# Altium schematic sheet [03] PD_SNK.SchDoc("[03] PD_SNK")
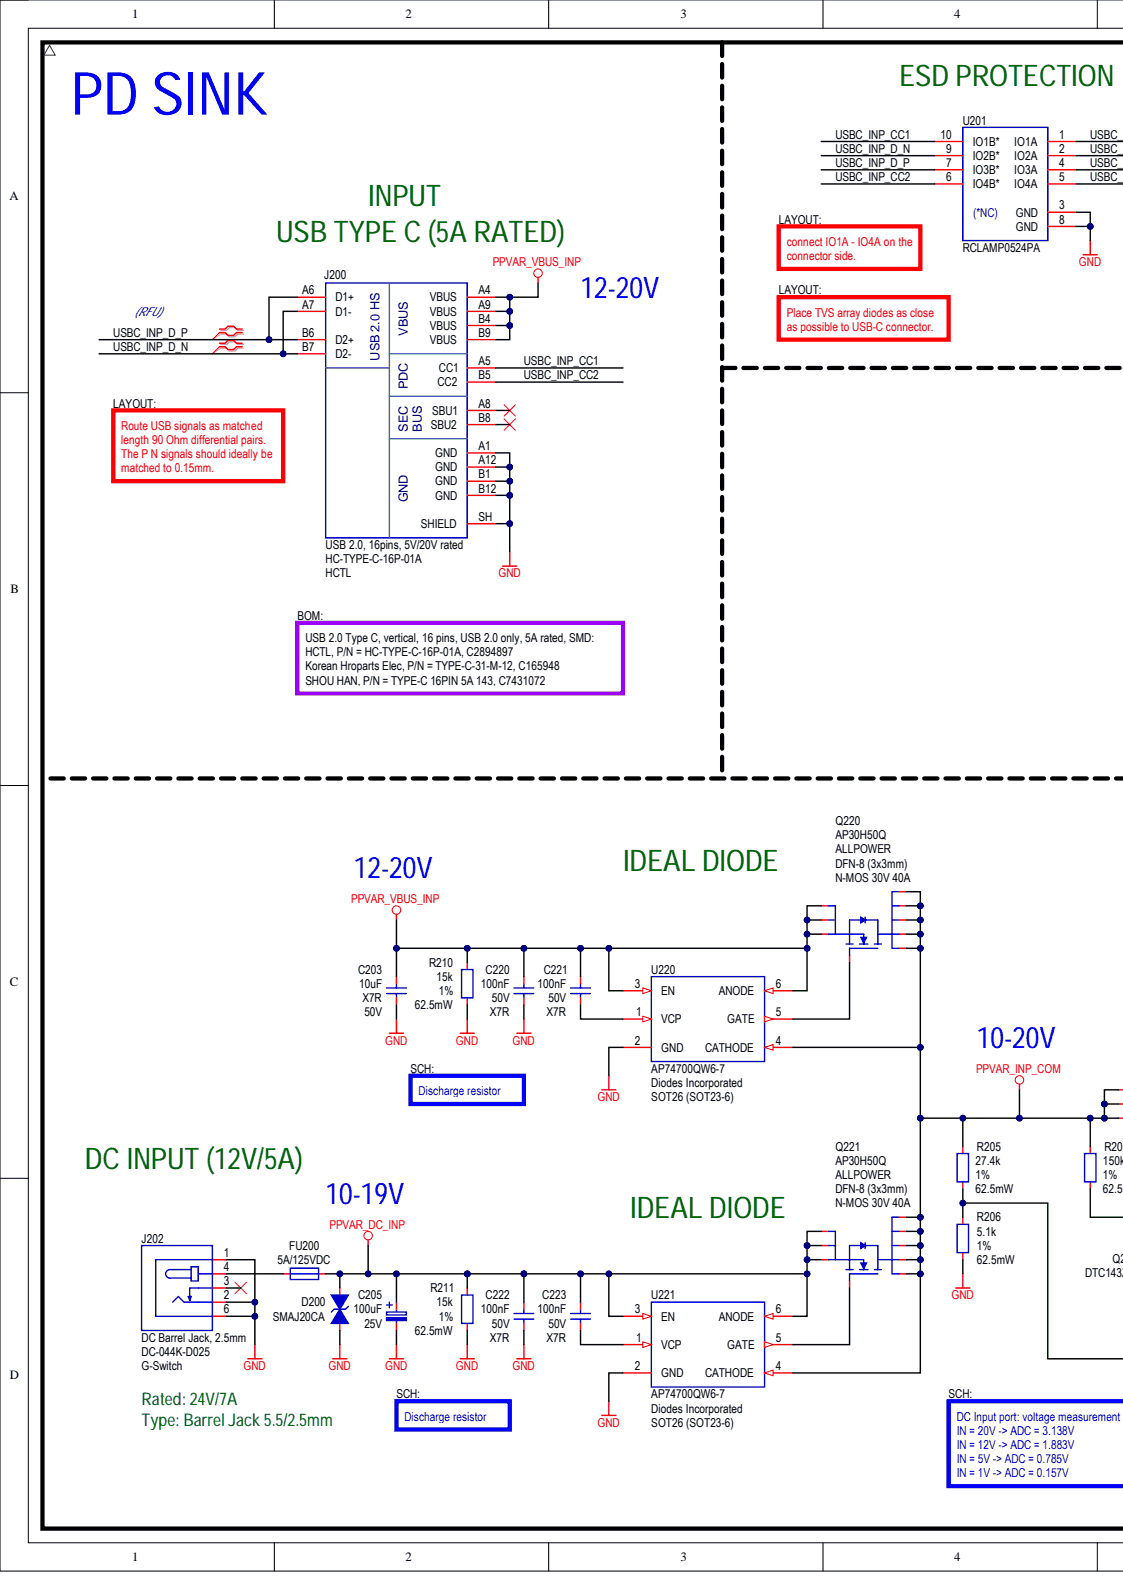
[diagram p0: [03] PD_SNK.SchDoc, left]
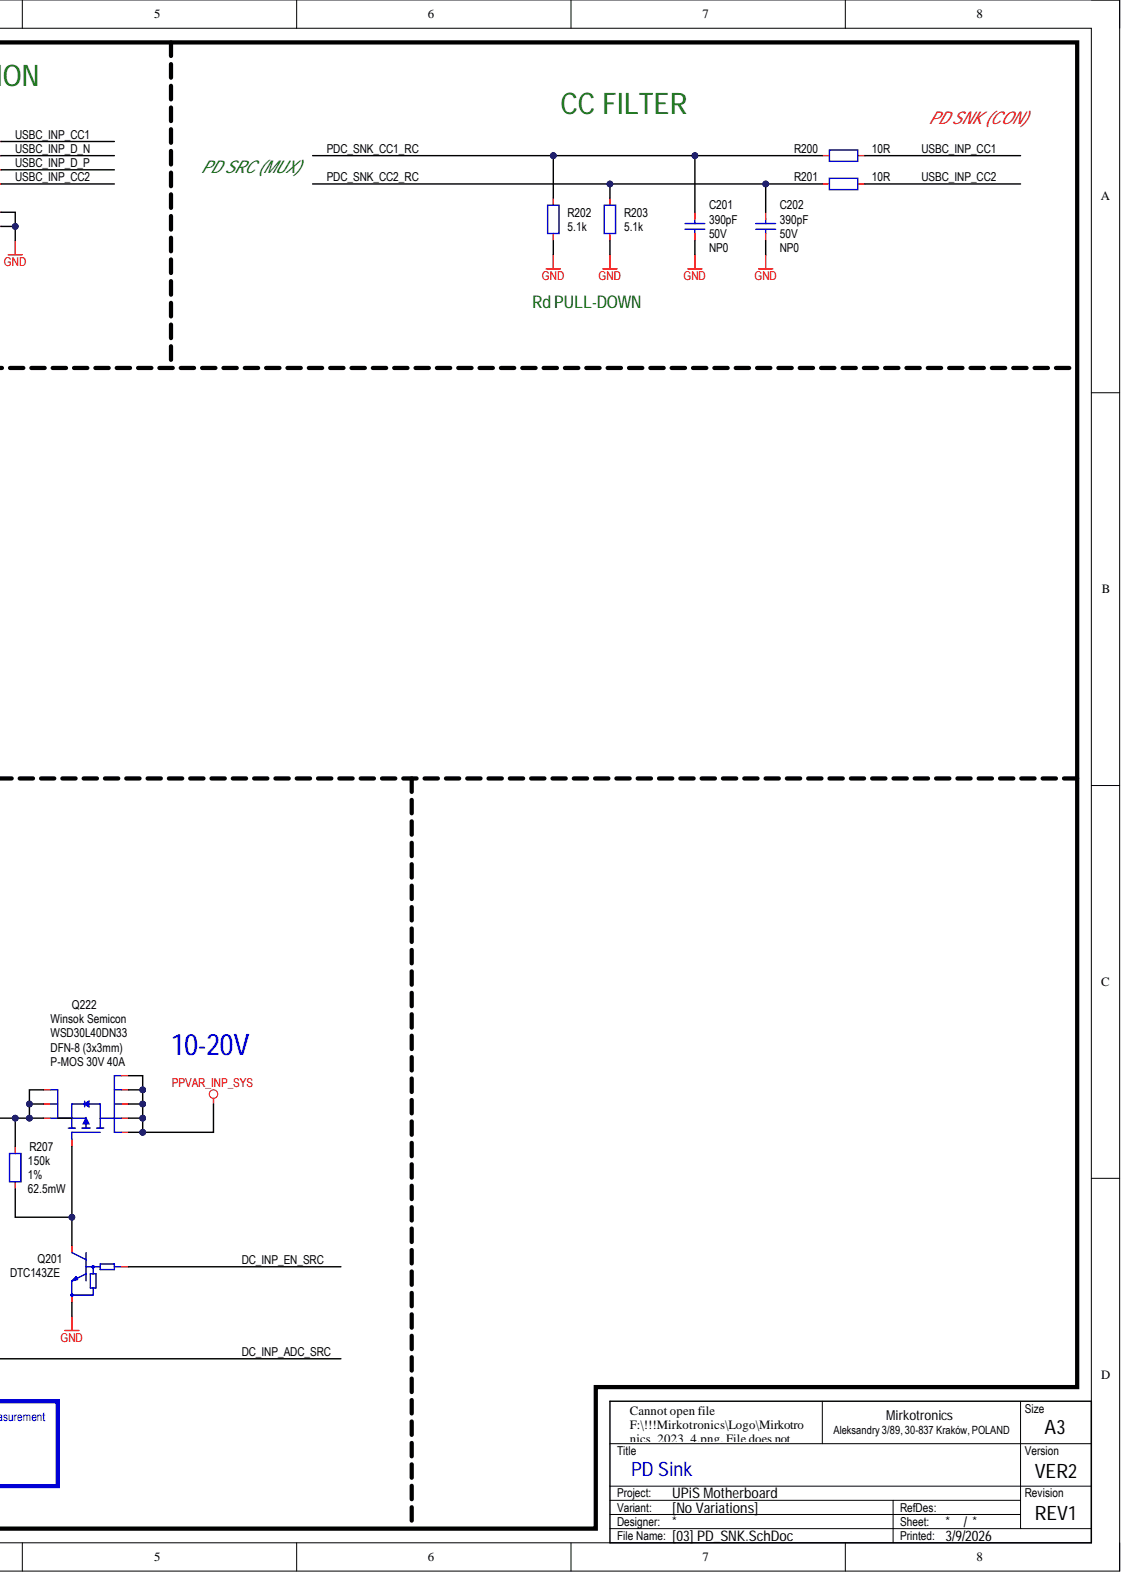
[diagram p1: [03] PD_SNK.SchDoc, right]

## Parts
C201  390pF  Dielectric: NP0  Voltage Rating: 50V
C202  390pF  Dielectric: NP0  Voltage Rating: 50V
C203  10uF  Dielectric: X7R  Voltage Rating: 50V
C205  100uF  Voltage Rating: 25V
C220  100nF  Dielectric: X7R  Voltage Rating: 50V
C221  100nF  Dielectric: X7R  Voltage Rating: 50V
C222  100nF  Dielectric: X7R  Voltage Rating: 50V
C223  100nF  Dielectric: X7R  Voltage Rating: 50V
D200  SMAJ20CA
FU200  5A/125VDC
J200  USB 2.0, 16pins, 5V/20V rated  Manufacturer: HCTL  MPN: HC-TYPE-C-16P-01A
J202  DC Barrel Jack, 2.5mm  Manufacturer: G-Switch  MPN: DC-044K-D025
Q201  DTC143ZE
Q220  N-MOS 30V 40A  Package (Case): DFN-8 (3x3mm)
Q221  N-MOS 30V 40A  Package (Case): DFN-8 (3x3mm)
Q222  P-MOS 30V 40A  MPN: WSD30L40DN33  Package (Case): DFN-8 (3x3mm)
R200  10R
R201  10R
R202  5.1k
R203  5.1k
R205  27.4k  Power Rating: 62.5mW  Tolerance: 1%
R206  5.1k  Power Rating: 62.5mW  Tolerance: 1%
R207  150k  Power Rating: 62.5mW  Tolerance: 1%
R210  15k  Power Rating: 62.5mW  Tolerance: 1%
R211  15k  Power Rating: 62.5mW  Tolerance: 1%
U201  RCLAMP0524PA
U220  AP74700QW6-7  Manufacturer: Diodes Incorporated  Package (Case): SOT26 (SOT23-6)
U221  AP74700QW6-7  Manufacturer: Diodes Incorporated  Package (Case): SOT26 (SOT23-6)

## Nets
GND: C201.1 C202.1 C203.1 C205.1 C220.2 C222.2 D200.1 J200.A1(GND) J200.A12(GND) J200.B1(GND) J200.B12(GND) J200.SH(SHIELD) J202.1 J202.2 J202.6 Q201.2 R202.1 R203.1 R206.1 R210.2 R211.2 U201.3(GND) U201.8(GND) U220.2(GND) U221.2(GND)
PPVAR_DC_INP: C205.2 C222.1 C223.1 D200.2 FU200.2 Q221.1 Q221.2 Q221.3 R211.1 U221.3(EN) U221.6(ANODE)
PPVAR_INP_COM: Q220.5 Q220.6 Q220.7 Q220.8 Q220.EP Q221.5 Q221.6 Q221.7 Q221.8 Q221.EP Q222.1 Q222.2 Q222.3 R205.1 R207.1 U220.4(CATHODE) U221.4(CATHODE)
PPVAR_INP_SYS: Q222.5 Q222.6 Q222.7 Q222.8 Q222.EP
PPVAR_VBUS_INP: C203.2 C220.1 C221.1 J200.A4(VBUS) J200.A9(VBUS) J200.B4(VBUS) J200.B9(VBUS) Q220.1 Q220.2 Q220.3 R210.1 U220.3(EN) U220.6(ANODE)
DC_INP_ADC_SRC: R205.2 R206.2
DC_INP_EN_SRC: Q201.1
PDC_SNK_CC1_RC: C201.2 R200.1 R202.2
PDC_SNK_CC2_RC: C202.2 R201.1 R203.2
USBC_INP_CC1: J200.A5(CC1) R200.2 U201.1(IO1A) U201.10(IO1B*)
USBC_INP_CC2: J200.B5(CC2) R201.2 U201.5(IO4A) U201.6(IO4B*)
USBC_INP_D_N: J200.A7(D1-) J200.B7(D2-) U201.2(IO2A) U201.9(IO2B*)
USBC_INP_D_P: J200.A6(D1+) J200.B6(D2+) U201.4(IO3A) U201.7(IO3B*)
NetC221_2: C221.2 U220.1(VCP)
NetC223_2: C223.2 U221.1(VCP)
NetFU200_1: FU200.1 J202.4
NetQ201_3: Q201.3 Q222.4 R207.2
NetQ220_4: Q220.4 U220.5(GATE)
NetQ221_4: Q221.4 U221.5(GATE)

Not connected: J200 A8(SBU1) B8(SBU2); J202 3

## Library data of the parts
C201 C202 = CAP-0023: Capacitor; MLCC; GENERIC; TBD; TBD; TBD; TBD; SMD; 0603 (1608);; Manufacturer: YAGEO; MPN: CC0402JRNPO9BN391; Database Table Name: CAPACITOR$; Package (Case): 0402 (1005); Tolerance: 5%; Capacitance: 390pF; Component Kind: Standard; Component Subtype: MLCC; Component Type: Capacitor; Mounting Type: SMD; Operating Temperature: TBD; Supplier 1: LCSC; Supplier 2: Mouser; Supplier 3: DigiKey; Supplier Part Number 1: C282239; Value: 390pF; Library Name: MirFol_db_local.DbLib
C203 = CAP-0025: Capacitor; MLCC; GENERIC; TBD; TBD; TBD; TBD; SMD; 1206 (3216);; Manufacturer: Samsung Electro-Mechanics; MPN: CL31B106KBHNNNE; Database Table Name: CAPACITOR$; Tolerance: 10%; Capacitance: 10uF; Component Body: TBD; Component Height: TBD; Component Kind: Standard; Component Subtype: MLCC; Component Type: Capacitor; Features: TBD; ID: CAP-0016; Mounting Type: SMD; Operating Temperature: TBD; Package (Case): 1206 (3216); Packaging Type: TBD; ROHS Status: TBD; Supplier 1: LCSC; Supplier 2: Mouser; Supplier 3: DigiKey; Supplier Part Number 1: C89632; Value: 10uF; Library Name: MirFol_db_local.DbLib
C205 = CAP_POL_2P_NP: Capacitor; Polarized;; Manufacturer: Panasonic; MPN: EEH-ZA1E101XP; Component Subtype: Electrolytic; Package (Case): SMD D8 (6.3x7.7mm); Capacitance: 100uF; Component Kind: Standard; Component Type: Capacitor; Mounting Type: SMD; Supplier 1: LCSC; Supplier 2: Mouser; Supplier Part Number 1: C264047; Supplier Part Number 2: 667-EEH-ZA1E101XP; Value: 100uF; Library Name: MirFol_lib.SchLib
C220-C223 = CAP-0004: Capacitor; MLCC; 100nF; 16VDC; X7R; 10%; Standard; SMD; 0402 (1005);; Manufacturer: Murata Electronics; MPN: GRM155R71H104KE14D; Component Body: 1.00 x 0.50mm; Database Table Name: CAPACITOR$; Packaging Type: Tape & Reel; Capacitance: 100nF; Component Height: 0.50mm; Component Kind: Standard; Component Subtype: MLCC; Component Type: Capacitor; Features: Standard; ID: CAP-0004; Mounting Type: SMD; Operating Temperature: -55°C to +125°C; Package (Case): 0402 (1005); ROHS Status: RoHS Compliant; Supplier 1: LCSC; Supplier 2: Mouser; Supplier 3: DigiKey; Supplier Part Number 1: C77020; Tolerance: 10%; Value: 100nF; Library Name: MirFol_db_local.DbLib
D200 = DIO_TVS_BID_P2_KA: Diode; TVS; Bipolar;; Manufacturer: MDD(Microdiode Semiconductor); Component Subtype: TVS Diode; Package (Case): SMA (DO-214AC); Component Kind: Standard; Component Type: Diode; Mounting Type: SMD; MPN: SMAJ20CA; Supplier 1: LCSC; Supplier Part Number 1: C113960; Value: SMAJ20CA; Library Name: Pineberry_Lib.SchLib
FU200 = FUS: Fuse; Manufacturer: Littelfuse; MPN: 0451005.MRL; Component Subtype: Disposable fuse; Component Kind: Standard; Component Type: Fuse; Mounting Type: SMD; Package (Case): SMD 2410; Supplier 1: LCSC; Supplier Part Number 1: C48467; Value: 5A/125VDC; Library Name: MirFol_lib.SchLib
J200 = CON_USB_TYPE_C_16P: Connector; USB 3.1 Type C; Receptacle; 24-pin; USB 2.0 Only;; Component Subtype: USB-C; Package (Case): SMD; Component Kind: Standard; Component Type: Connector; Mounting Type: SMD; Supplier 1: LCSC; Supplier 2: Mouser; Supplier 3: DigiKey; Supplier Part Number 1: C2894897; Value: USB 2.0, 16pins, 5V/20V rated; Library Name: MirFol_lib.SchLib
J202 = CON_GSW_DC-044K-D025: Connector; DC Barrel Jack;; Package (Case): THT; Component Kind: Standard; Component Subtype: Barrel jack; Component Type: Connector; Mounting Type: THT; Supplier 1: LCSC; Supplier 2: Mouser; Supplier 3: DigiKey; Supplier Part Number 1: C963204; Value: DC Barrel Jack, 2.5mm; Library Name: Pineberry_Lib.SchLib
Q201 = TRA_BJT_NPN_DIG_BEC: Transistor; BJT; NPN; Digital;; Manufacturer: Jiangsu Changjing Electronics Technology Co., Ltd.; Component Subtype: NPN Transistor; Package (Case): SOT-523; Component Kind: Standard; Component Type: Transistor; Mounting Type: SMD; MPN: DTC143ZE; Supplier 1: LCSC; Supplier 2: Mouser; Supplier 3: DigiKey; Supplier Part Number 1: C78593; Value: DTC143ZE; Library Name: MirFol_lib.SchLib
Q220 Q221 = TRA_NMOS_SSSGDDDD_EP: Transistor; NMOS;; Manufacturer: ALLPOWER; MPN: AP30H50Q; Component Subtype: P-MOSFET; Component Kind: Standard; Component Type: Transistor; Mounting Type: SMD; Supplier 1: LCSC; Supplier 2: Mouser; Supplier 3: Digikey; Supplier Part Number 1: C2849568; Value: N-MOS 30V 40A; Library Name: Pineberry_Lib.SchLib
Q222 = TRA_PMOS_SSSGDDDD_EP: Transistor; PMOS;; Manufacturer: Winsok Semicon; Component Subtype: P-MOSFET; Component Kind: Standard; Component Type: Transistor; Mounting Type: SMD; Supplier 1: LCSC; Supplier 2: Mouser; Supplier 3: Digikey; Supplier Part Number 1: C148390; Value: P-MOS 30V 40A; Library Name: Pineberry_Lib.SchLib
R200 R201 = RES-0003: Resistor; Thick Film; 1k; 1%; 62.5mW; 100PPM; 50V; Standard; SMD; 0402 (1005);; Manufacturer: Yageo Corporation; MPN: RC0402FR-0710RL; Component Body: 1.00 x 0.50mm; Database Table Name: RESISTOR$; Packaging Type: Tape & Reel; Component Height: 0.35mm; Component Kind: Standard; Component Subtype: Thick Film; Component Type: Resistor; Features: Standard; ID: RES-0003; Mounting Type: SMD; Operating Temperature: -55°C to +155°C; Package (Case): 0402 (1005); Power Rating: 62.5mW; Resistance: 10R; ROHS Status: RoHS Compliant; Supplier 1: LCSC; Supplier 2: Mouser; Supplier 3: Digikey; Supplier Part Number 1: C138066; TCR: 100PPM; Tolerance: 1%; Value: 10R; Voltage Rating: 50V; Library Name: MirFol_db_local.DbLib
R202 R203 = RES-0058: Resistor; Thick Film; GENERIC; TBD; TBD; TBD; TBD; TBD; SMD; 0402 (1005);; Manufacturer: YAGEO; MPN: RC0402FR-075K1L; Component Body: 1.00 x 0.50mm; Database Table Name: RESISTOR$; Packaging Type: Tape & Reel; Power Rating: 62.5mW; TCR: 100PPM; Tolerance: 1%; Voltage Rating: 50V; Component Height: 0.35mm; Component Kind: Standard; Component Subtype: Thick Film; Component Type: Resistor; Features: Standard; ID: RES-0058; Mounting Type: SMD; Operating Temperature: -55°C to +155°C; Package (Case): 0402 (1005); Resistance: 5.1k; ROHS Status: RoHS Compliant; Supplier 1: LCSC; Supplier 2: Mouser; Supplier 3: Digikey; Supplier Part Number 1: C105872; Supplier Part Number 2: 603-RC0402FR-075K1L; Supplier Part Number 3: 311-5.10KLRTR-ND; Value: 5.1k; Library Name: MirFol_db_local.DbLib
R205 = RES-0003: Resistor; Thick Film; 1k; 1%; 62.5mW; 100PPM; 50V; Standard; SMD; 0402 (1005);; Manufacturer: Yageo Corporation; MPN: RC0402FR-0727K4L; Component Body: 1.00 x 0.50mm; Database Table Name: RESISTOR$; Packaging Type: Tape & Reel; Component Height: 0.35mm; Component Kind: Standard; Component Subtype: Thick Film; Component Type: Resistor; Features: Standard; ID: RES-0003; Mounting Type: SMD; Operating Temperature: -55°C to +155°C; Package (Case): 0402 (1005); Resistance: 27.4k; ROHS Status: RoHS Compliant; Supplier 1: LCSC; Supplier 2: Mouser; Supplier 3: Digikey; Supplier Part Number 1: C273730; TCR: 100PPM; Value: 27.4k; Voltage Rating: 50V; Library Name: MirFol_db_local.DbLib
R206 = RES-0058: Resistor; Thick Film; GENERIC; TBD; TBD; TBD; TBD; TBD; SMD; 0402 (1005);; Manufacturer: YAGEO; MPN: RC0402FR-075K1L; Component Body: 1.00 x 0.50mm; Database Table Name: RESISTOR$; Packaging Type: Tape & Reel; TCR: 100PPM; Voltage Rating: 50V; Component Height: 0.35mm; Component Kind: Standard; Component Subtype: Thick Film; Component Type: Resistor; Features: Standard; ID: RES-0058; Mounting Type: SMD; Operating Temperature: -55°C to +155°C; Package (Case): 0402 (1005); Resistance: 5.1k; ROHS Status: RoHS Compliant; Supplier 1: LCSC; Supplier 2: Mouser; Supplier 3: Digikey; Supplier Part Number 1: C105872; Supplier Part Number 2: 603-RC0402FR-075K1L; Supplier Part Number 3: 311-5.10KLRTR-ND; Value: 5.1k; Library Name: MirFol_db_local.DbLib
R207 = RES-0003: Resistor; Thick Film; 1k; 1%; 62.5mW; 100PPM; 50V; Standard; SMD; 0402 (1005);; Manufacturer: Yageo Corporation; MPN: RC0402FR-07150KL; Component Body: 1.00 x 0.50mm; Database Table Name: RESISTOR$; Packaging Type: Tape & Reel; Component Height: 0.35mm; Component Kind: Standard; Component Subtype: Thick Film; Component Type: Resistor; Features: Standard; ID: RES-0003; Mounting Type: SMD; Operating Temperature: -55°C to +155°C; Package (Case): 0402 (1005); Resistance: 150k; ROHS Status: RoHS Compliant; Supplier 1: LCSC; Supplier 2: Mouser; Supplier 3: Digikey; Supplier Part Number 1: C93947; TCR: 100PPM; Value: 150k; Voltage Rating: 50V; Library Name: MirFol_db_local.DbLib
R210 R211 = RES-0058: Resistor; Thick Film; GENERIC; TBD; TBD; TBD; TBD; TBD; SMD; 0402 (1005);; Manufacturer: YAGEO; MPN: RC0402FR-0715KL; Component Body: 1.00 x 0.50mm; Database Table Name: RESISTOR$; Packaging Type: Tape & Reel; TCR: 100PPM; Voltage Rating: 50V; Component Height: 0.35mm; Component Kind: Standard; Component Subtype: Thick Film; Component Type: Resistor; Features: Standard; ID: RES-0058; Mounting Type: SMD; Operating Temperature: -55°C to +155°C; Package (Case): 0402 (1005); Resistance: 15k; ROHS Status: RoHS Compliant; Supplier 1: LCSC; Supplier 2: Mouser; Supplier 3: Digikey; Supplier Part Number 1: C114761; Supplier Part Number 2: 603-RC0402FR-0715KL; Supplier Part Number 3: 311-15.0KLRTR-ND; Value: 15k; Library Name: MirFol_db_local.DbLib
U201 = ICC-0005: IC; TVS Arrays; ESD Protection; 4-Channel; 17kV Air; 12kV Contact; 0.3pF Capitance; TBD; SMD; TBD;; Manufacturer: Semtech Corporation; MPN: RCLAMP0524PATCT; Database Table Name: IC (MISC)$; Component Kind: Standard; Component Subtype: TVS Arrays; Component Type: IC; Mounting Type: SMD; Package (Case): SMD; Supplier 1: LCSC; Supplier Part Number 1: C40960; Value: RCLAMP0524PA; Library Name: MirFol_db_local.DbLib
U220 U221 = ICC_DDI_AP74700Q_SOT26: IC; Ideal diode controller;; Component Kind: Standard; Component Subtype: Ideal diode controller; Component Type: IC; Mounting Type: SMD; MPN: AP74700QW6-7; Supplier 1: LCSC; Supplier Part Number 1: C5381661; Value: AP74700QW6-7; Library Name: Pineberry_Lib.SchLib
